# Altium schematic sheet Gateway_Solar_Board.SchDoc
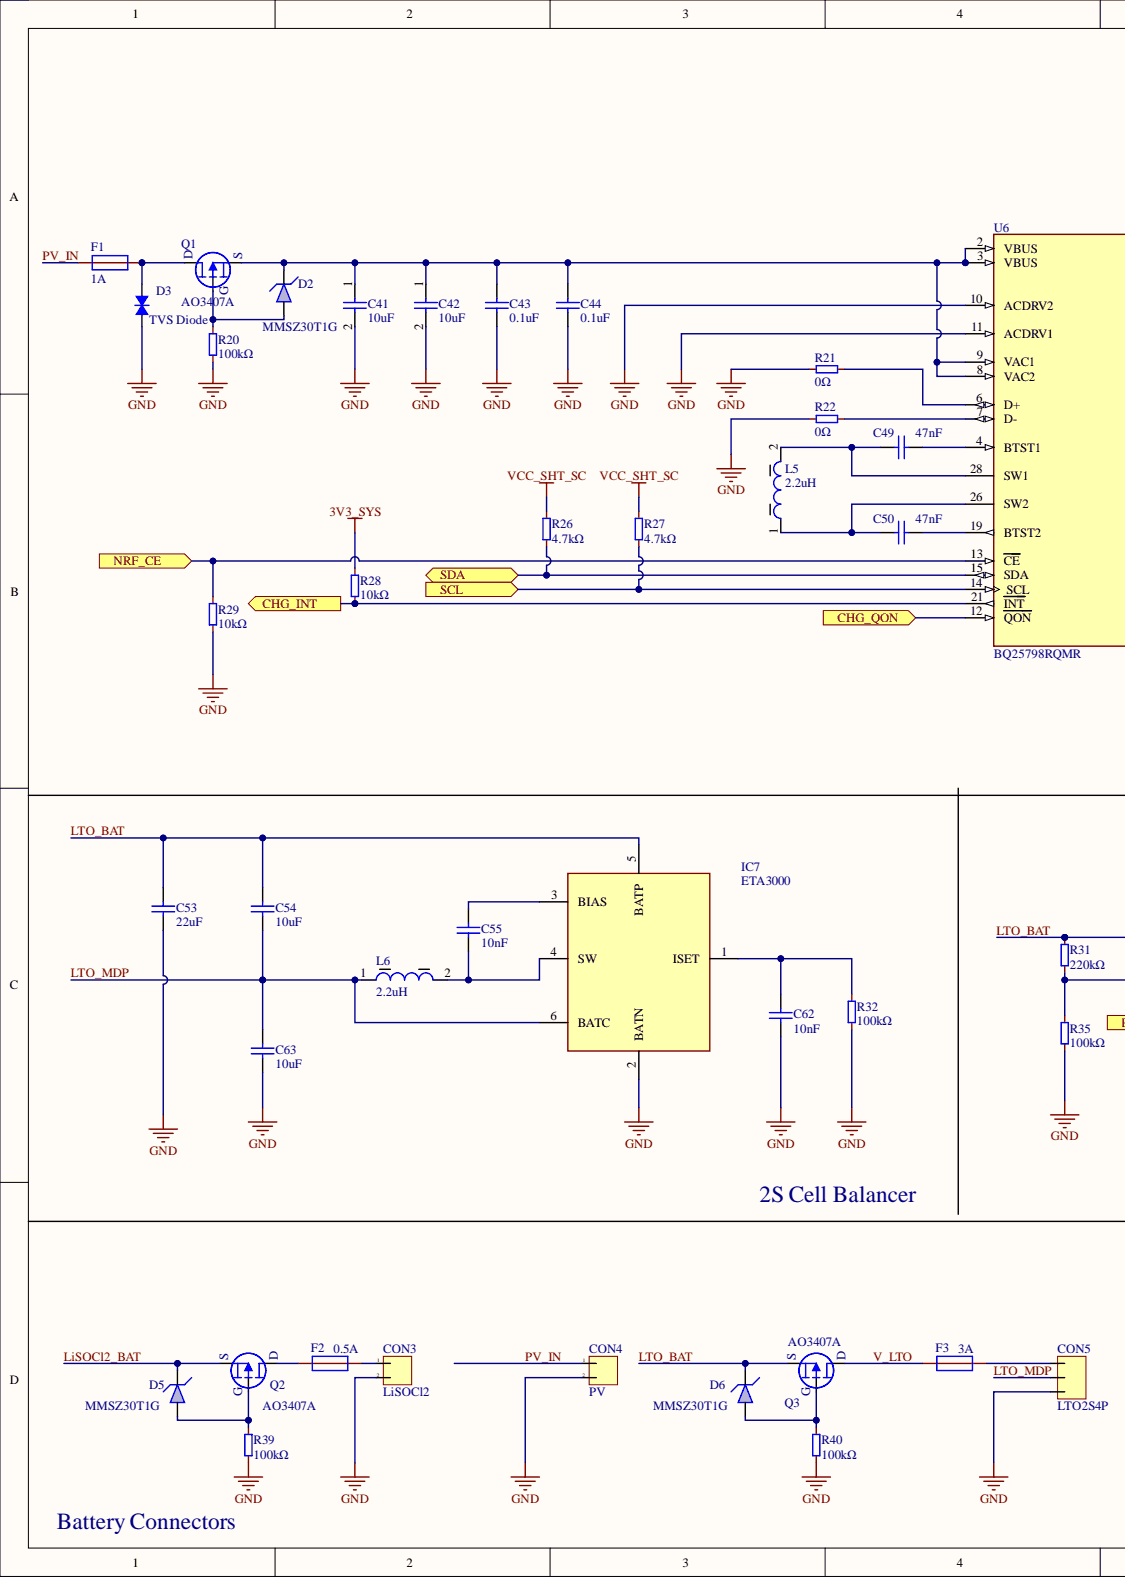
[diagram p0: Gateway_Solar_Board.SchDoc, left]
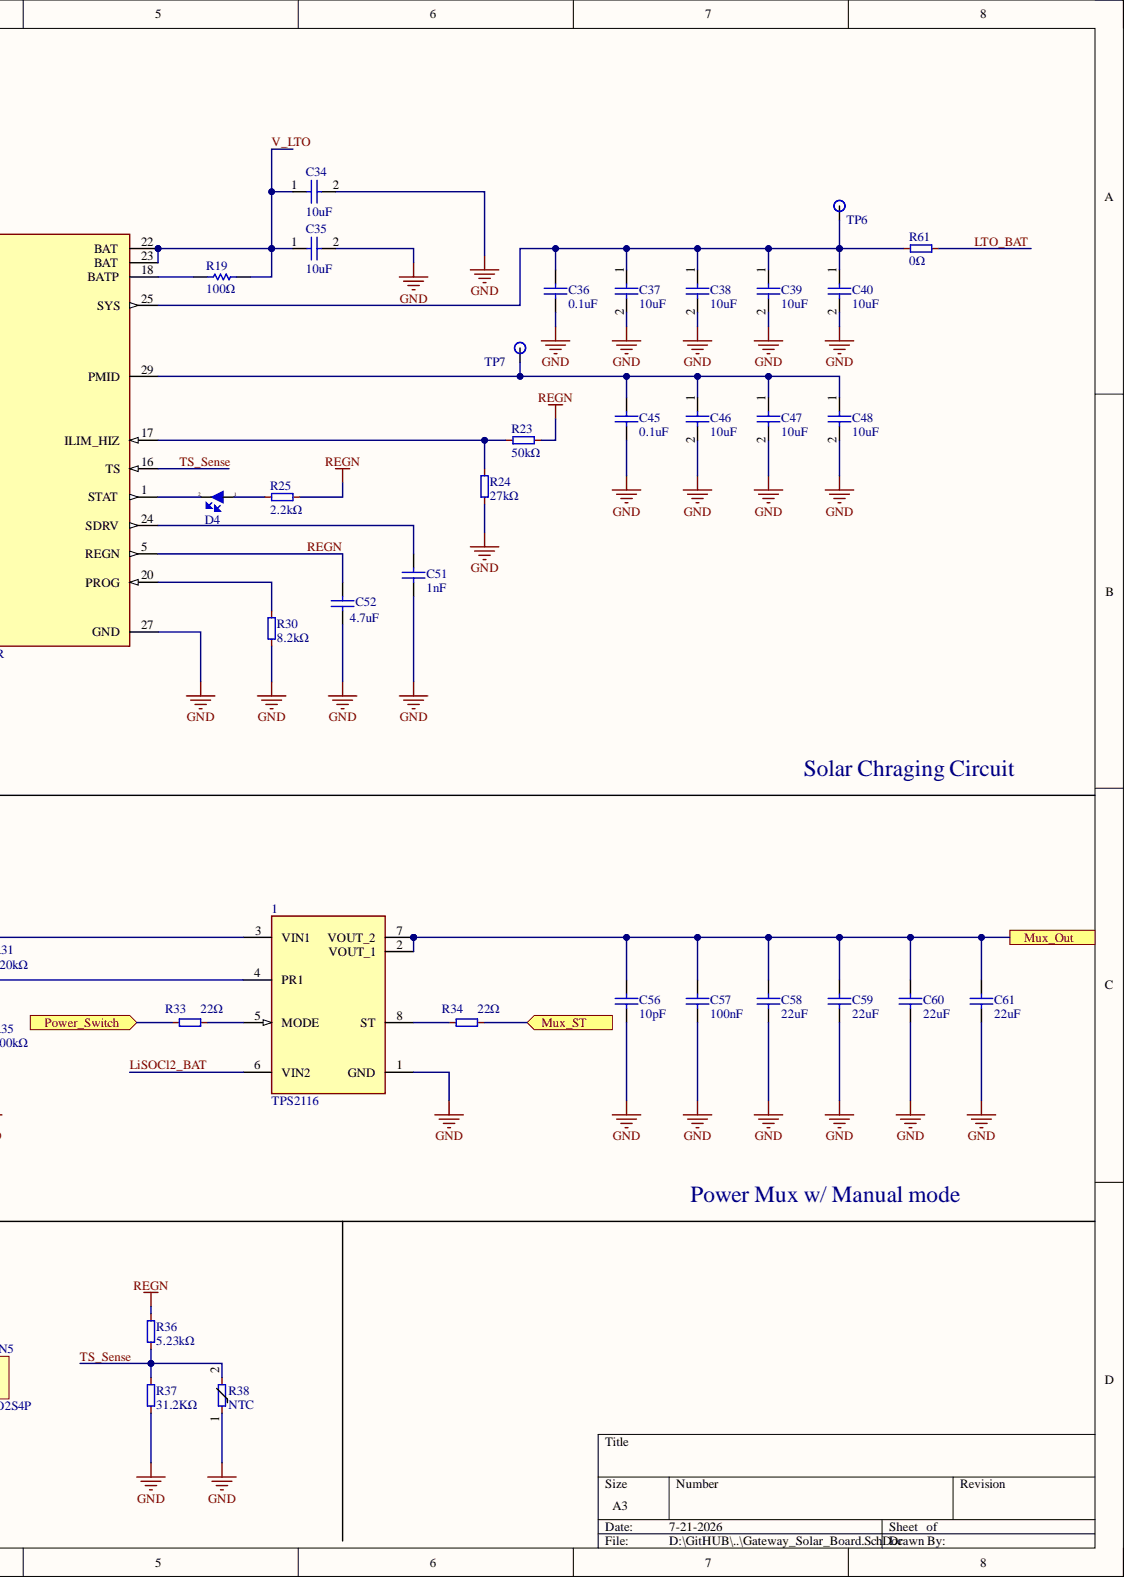
[diagram p1: Gateway_Solar_Board.SchDoc, right]

## Parts
1  TPS2116  [TPS2116]
C34  10uF  [Cap_0805]
C35  10uF  [Cap_0805]
C36  0.1uF  [CAP0402]
C37  10uF  [Cap_0805]
C38  10uF  [Cap_0805]
C39  10uF  [Cap_0805]
C40  10uF  [Cap_0805]
C41  10uF  [Cap_0805]
C42  10uF  [Cap_0805]
C43  0.1uF  [CAP0402]
C44  0.1uF  [CAP0402]
C45  0.1uF  [CAP0402]
C46  10uF  [Cap_0805]
C47  10uF  [Cap_0805]
C48  10uF  [Cap_0805]
C49  47nF  [CAP0402]
C50  47nF  [CAP0402]
C51  value 1nF  [CAPC1005X06N]  (hidden comment: MCD-00198)
C52  4.7uF  [CAP0402]
C53  22uF  [CAP0402]
C54  10uF  [CAP0402]
C55  10nF  [CAP0402]
C56  10pF  [CAP0402]
C57  100nF  [CAP0402]
C58  22uF  [CAP0402]
C59  22uF  [CAP0402]
C60  22uF  [CAP0402]
C61  22uF  [CAP0402]
C62  10nF  [CAP0402]
C63  10uF  [CAP0402]
CON3  LiSOCl2  [2 Pin Relimate 2.54mm Straight]
CON4  PV  [2 Pin Relimate 2.54mm Straight]
CON5  LTO2S4P  [3 Pin Relimate]
D2  MMSZ30T1G  [SOD 80]
D3  TVS Diode  [Diode SMD 214AC]
D4  COLOR  [L_0805]  (value not drawn)
D5  MMSZ30T1G  [SOD 80]
D6  MMSZ30T1G  [SOD 80]
F1  1A  [Fuse - 1206]
F2  0.5A  [Fuse - 1206]
F3  3A  [Fuse - 1206]
IC7  ETA3000  [ETA3000]
L5  2.2uH  [Ind 2.2uH]
L6  2.2uH  [Ind 2.2uH]
Q1  AO3407A  [SOT23]
Q2  AO3407A  [SOT23]
Q3  AO3407A  [SOT23]
R19  100Ω  [RESC0402]
R20  100kΩ  [RESC0402]
R21  0Ω  [RESC0402]
R22  0Ω  [RESC0402]
R23  50kΩ  [RESC0402]
R24  27kΩ  [RESC0402]
R25  2.2kΩ  [RESC0402]
R26  4.7kΩ  [RESC0402]
R27  4.7kΩ  [RESC0402]
R28  10kΩ  [RESC0402]
R29  10kΩ  [RESC0402]
R30  8.2kΩ  [RESC0402]
R31  220kΩ  [RESC0402]
R32  100kΩ  [RESC0402]
R33  22Ω  [RESC0402]
R34  22Ω  [RESC0402]
R35  100kΩ  [RESC0402]
R36  5.23kΩ  [RESC0805]
R37  31.2KΩ  [RESC0805]
R38  NTC  [2 Pin Relimate 2.54mm Straight]
R39  100kΩ  [RESC0402]
R40  100kΩ  [RESC0402]
R61  0Ω  [RESC0402]
TP6  Test Point  [Test Point]  (value not drawn)  (no BOM)
TP7  Test Point  [Test Point]  (value not drawn)  (no BOM)
U6  BQ25798RQMR  [BQ25798RQMR]

## Ports
CHG_INT, CHG_QON, Mux_Out, Mux_ST, NRF_CE, Power_Switch, SCL, SDA

## Nets
3V3_SYS: R28.2
GND: 1.1(GND) C34.2 C35.2 C36.1 C37.2 C38.2 C39.2 C40.2 C41.2 C42.2 C43.1 C44.1 C45.1 C46.2 C47.2 C48.2 C51.1 C52.1 C53.1 C56.2 C57.2 C58.1 C59.1 C60.1 C61.1 C62.1 C63.2 CON3.2 CON4.2 CON5.3 D3.2 IC7.2(BATN) R20.1 R21.1 R22.1 R24.2 R29.1 R30.1 R32.1 R35.1 R37.1 R38.1 R39.1 R40.1 U6.10(ACDRV2) U6.11(ACDRV1) U6.27(GND)
VCC_SHT_SC: R26.2 R27.2
CHG_INT: R28.1 U6.21(INT) ; port CHG_INT
CHG_QON: U6.12(QON) ; port CHG_QON
LiSOCl2_BAT: 1.6(VIN2) D5.1 Q2.2
LTO_BAT: 1.3(VIN1) C53.2 C54.1 D6.1 IC7.5(BATP) Q3.2 R31.2 R61.1
LTO_MDP: C54.2 C63.1 CON5.2 IC7.6(BATC) L6.1
Mux_Out: 1.2(VOUT_1) 1.7(VOUT_2) C56.1 C57.1 C58.2 C59.2 C60.2 C61.2 ; port Mux_Out
Mux_ST: R34.2 ; port Mux_ST
NRF_CE: R29.2 U6.13(CE) ; port NRF_CE
Power_Switch: R33.1 ; port Power_Switch
PV_IN: CON4.1 F1.2
REGN: C52.2 R23.2 R25.1 R36.2 U6.5(REGN)
SCL: R27.1 U6.14(SCL) ; port SCL
SDA: R26.1 U6.15(SDA) ; port SDA
TS_Sense: R36.1 R37.2 R38.2 U6.16(TS)
V_LTO: C34.1 C35.1 F3.1 Q3.3 R19.2 U6.22(BAT) U6.23(BAT)
Net1_4: 1.4(PR1) R31.1 R35.2
Net1_5: 1.5(MODE) R33.2
Net1_8: 1.8(ST) R34.1
NetC36_2: C36.2 C37.1 C38.1 C39.1 C40.1 R61.2 TP6.1 U6.25(SYS)
NetC41_1: C41.1 C42.1 C43.2 C44.2 D2.1 Q1.2 U6.2(VBUS) U6.3(VBUS) U6.8(VAC2) U6.9(VAC1)
NetC45_2: C45.2 C46.1 C47.1 C48.1 TP7.1 U6.29(PMID)
NetC49_1: C49.1 L5.2 U6.28(SW1)
NetC49_2: C49.2 U6.4(BTST1)
NetC50_1: C50.1 L5.1 U6.26(SW2)
NetC50_2: C50.2 U6.19(BTST2)
NetC51_2: C51.2 U6.24(SDRV)
NetC55_1: C55.1 IC7.4(SW) L6.2
NetC55_2: C55.2 IC7.3(BIAS)
NetC62_2: C62.2 IC7.1(ISET) R32.2
NetCON3_1: CON3.1 F2.2
NetCON5_1: CON5.1 F3.2
NetD2_2: D2.2 Q1.1 R20.2
NetD3_1: D3.1 F1.1 Q1.3
NetD4_1: D4.1 R25.2
NetD4_2: D4.2 U6.1(STAT)
NetD5_2: D5.2 Q2.1 R39.2
NetD6_2: D6.2 Q3.1 R40.2
NetF2_1: F2.1 Q2.3
NetR19_1: R19.1 U6.18(BATP)
NetR21_2: R21.2 U6.6(D+)
NetR22_2: R22.2 U6.7(D-)
NetR23_1: R23.1 R24.1 U6.17(ILIM_HIZ)
NetR30_2: R30.2 U6.20(PROG)

## Library data of the parts
1 = TPS2116: 1.6 V to 5.5 V, 2.5-A Low IQ Power Mux; Manufacturer: Texas Instruments; Manufacturer_Part_Number: TPS2116DRLR; Component Kind: Standard; Supplier 1: Digikey; Supplier Part Number 1: TPS2116DRLR; Library Name: macnman_3.0.SchLib
C34 C35 C37-C42 C46-C48 = Cap_0805: Ceramic Capacitor - SMD 0805 10uF 10% 25V X7R; Manufacturer_Part_Number: CL21B106KAYQNNE; Component Kind: Standard; Supplier 1: Evalta; Supplier 2: Robu; Supplier Part Number 1: CL21B106KAYQNNE; Supplier Part Number 2: CL21B106KAYQNNE; Library Name: macnman_3.0.SchLib
C36 C43-C45 = CAP_0402: Ceramic Capacitor - SMD 0.1uF X7R 0402 50V 10%; Manufacturer: Samsung Electro Mechanics; Manufacturer_Part_Number: CL05B104KB54PNC; Component Kind: Standard; Package: 0402; Supplier 1: Evalta; Supplier 2: Robu; Supplier Part Number 1: CL05B104KB54PNC; Supplier Part Number 2: CL05B104KB54PNC; Library Name: macnman_2.0.SchLib
C49 C50 = CAP_0402: Ceramic Capacitor - SMD 7nF X7R 0402 10% 50V; Manufacturer: Samsung Electro Mechanics; Manufacturer_Part_Number: CL05B473KB5VPNC; Component Kind: Standard; Package: 0402; Supplier 1: Robu; Supplier 2: Digikey; Supplier Part Number 1: CL05B473KB5VPNC; Supplier Part Number 2: 1276-CL05B473KB5VPNCCT-ND; Library Name: macnman_2.0.SchLib
C51 = MCD-00198: Ceramic Capacitor - SMD 1nF 25V C0G 5% 0402; Manufacturer: Murata; Manufacturer_Part_Number: GRT1555C1E102JA02J; Component Kind: Standard; Supplier 1: DigiKey; Supplier 2: Mouser; Supplier Part Number 1: 490-GRT1555C1E102JA02JCT-ND; Supplier Part Number 2: 81-GRT1555C1E102JA2J; Library Name: macnman_3.0.SchLib
C52 = CAP_0402: Ceramic Capacitor - SMD X7R 0402 4.7uF 10V 20%; Manufacturer: MURATA; Manufacturer_Part_Number: GRT155R61A475ME13D; Component Kind: Standard; Package: 0402; Supplier 1: Robu; Supplier 2: DigiKey; Supplier Part Number 1: GRT155R61A475ME13D; Supplier Part Number 2: 490-GRT155R61A475ME13DCT-ND; Library Name: macnman_2.0.SchLib
C53 C58-C61 = CAP_0402: Ceramic Capacitor - SMD 22uF X5R 0402 20% 6.3V; Manufacturer: Yageo; Manufacturer_Part_Number: GRM158R61A226ME15D; Component Kind: Standard; Supplier: Robu; Supplier_Part_Number: GRM158R61A226ME15D; Library Name: macnman_2.0.SchLib
C54 C63 = CAP_0402: Ceramic Capacitor - SMD 0402 10uF 20% 6.3V X5R; Manufacturer: MURATA; Manufacturer_Part_Number: GRM155R60J106ME15D; Component Kind: Standard; Supplier: Robu; Supplier_Part_Number: GRM155R60J106ME15D; Library Name: macnman_2.0.SchLib
C55 C62 = CAP_0402: Ceramic Capacitor - SMD C0G 0402 10nF 50V 5%; Manufacturer: MURATA; Manufacturer_Part_Number: GRM1555C1H103JE01D; Component Kind: Standard; Package: 0402; Supplier 1: Robu; Supplier Part Number 1: GRM1555C1H103JE01D; Library Name: macnman_2.0.SchLib
C56 = Capa_0402: Ceramic Capacitor C0G, NP0 0402 10pF 35V; Manufacturer: YAGEO; Manufacturer_Part_Number: CC0402JRNPO9BN100; Component Kind: Standard; Library Name: macnman_2.0.SchLib
C57 = Capa_0402: Ceramic Capacitor C0G, NP0 0402 100nF 35V; Manufacturer: MURATA; Manufacturer_Part_Number: GRM155R61A104KA01J; Component Kind: Standard; Library Name: macnman_2.0.SchLib
CON3 CON4 = 2 Pin Relimate: 2 Pin Connector; Manufacturer: Generic; Component Kind: Net Tie; Manufacturer_Part_Number: Generic; Supplier-1 Part No: 5221; Library Name: macnman_3.0.SchLib
CON5 = 3 Pin Relimate: 3 Pin Connector; Manufacturer: Generic; Component Kind: Net Tie; Manufacturer_Part_Number: Generic; Package: 2.54 3POS; Library Name: macnman_3.0.SchLib
D2 D5 = ZENER_DIODE: Zener Diode  3V3 SOD-80 1/5WATT; Manufacturer: Onsemi; Parameter 1: MMSZ30T1Gv; Suplier: Robu; Component Kind: Standard; Manufacturer_Part_Number: MMSZ30T1G; Package: SOD-80; Library Name: macnman_2.0.SchLib
D3 = TVS Diode: 0.35pF 20kV Bidirectional Discrete TVS; Manufacturer: Littelfuse; Manufacturer_Part_Number: SMBJ16A; Component Kind: Standard; Library Name: macnman_3.0.SchLib
D4 = L0805: LED 0805 SMD; Manufacturer: Everlight; Manufacturer_Part_Number: 17-21SURC/S530-A3/4T; Component Kind: Standard; Supplier 1: Evalta; Supplier 2: Sharvi; Supplier Part Number 1: 17-21SURC/S530-A3/4T; Supplier Part Number 2: ST2103SD2639; Library Name: macnman_3.0.SchLib
D6 = ZENER_DIODE: Zener Diode  3V3 SOD-80 1/5WATT; Manufacturer: Onsemi; Suplier: Robu; Component Kind: Standard; Manufacturer_Part_Number: MMSZ30T1G; Package: SOD-80; Parameter 1: MMSZ30T1G; Library Name: macnman_2.0.SchLib
F1 = Fuse: 1 A 63 V 63 V Fuse Board Mount (Cartridge Style Excluded) Surface Mount 1206 (3216 Metric); Manufacture: Bel Fuse Inc; Manufacturer_Part_Number: 0685T1000-01; Component Kind: Standard; Supplier 1: Digikey; Supplier Part Number 1: 0685T1000-01; Library Name: macnman_2.0.SchLib
F2 = Fuse: 500 mA 63 V Fuse Board Mount (Cartridge Style Excluded) Surface Mount 1206 (3216 Metric); Manufacture: Yageo; Manufacturer_Part_Number: JB12S5000R; Component Kind: Standard; Supplier 1: Digikey; Supplier Part Number 1: JB12S5000R; Library Name: macnman_2.0.SchLib
F3 = Fuse: 3 A 63 V 50A Fuse Board Mount Surface Mount 1206 (3216 Metric); Manufacture: Bel Fuse Inc; Manufacturer_Part_Number: 0685T3000-01; Component Kind: Standard; Supplier 1: Digikey; Supplier Part Number 1: 0685T3000-01; Library Name: macnman_2.0.SchLib
IC7 = ETA3000: Inductive 2S Cell Balancer IC, 2uA Iq, 2A Imax; Manfacturer: ETA Solution; Component Kind: Standard; Manufacturer_Part_Number: ETA3000; Supplier: Alibaba; Supplier_Part_Number: ETA3000; Library Name: macnman_3.0.SchLib
L5 L6 = Inductor: FIXED Imductor - SMD 2.2UH 6.5A 20 MOHM 20%; Manufacturer: Wurth; Manufacturer_Part_Number: 74437346022; Component Kind: Standard; Library Name: Inductor_2.2uH.SchLib; Supplier 1: DigiKey; Supplier Part Number 1: 732-3372-1-ND
Q1 Q2 = MOS_P_Chann: MOSDET P-CHANNEL SOT-23 4A 30V; Manufacturer: Alpha and Omega Semiconductor; Component Kind: Standard; Manufacturer_Part_Number: AO3407A; Package: SOT-23; Parameter 1: AO3407A; Supplier: Robu; Library Name: macnman_2.0.SchLib
Q3 = MOS_P_Chann: MOSDET P-CHANNEL SOT-23 4A 30V; Manufacturer: Alpha and Omega Semiconductors; Component Kind: Standard; Manufacturer_Part_Number: AO3407A; Package: SOT-23; Supplier: Robu; Supplier_Part_Number: AO3407A; Library Name: macnman_2.0.SchLib
R19 = MCD-00119: Resistor 100Ω 0402 1% 1/16W; Manufacturer: YAGEO; Manufacturer_Part_Number: RC0402FR-07100RL; Component Kind: Standard; Value: 100Ω; Library Name: macnman_3.0.SchLib
R20 R32 R35 R39 R40 = Resister: Thick Flim Resistor - SMD 100k 0805 0.5% 100ppm 1/8W; Manufacturer: Yageo; Manufacturer_Part_Number: RC0402DR-07100KL; Component Kind: Standard; Package: 0805; Supplier: Robu; Supplier_Part_Number: RC0402DR-07100KL; Library Name: macnman_3.0.SchLib
R21 R22 R61 = RES_0402: Thick Film Resistors - SMD 0Ohm 0402 1/16W; Manufacturer: YAGEO; Manufacturer_Part_Number: RC0402FR-070RL; Component Kind: Standard; ComponentLink1Description: New link; Supplier: Robu; Supplier_Part_Number: RC0402FR-070RL; Library Name: macnman_2.0.SchLib
R23 = RES_0603: Thin Film Resistors 50K 1% 50PPM 1/1 6W 0402; Manufacturer: YAGEO; Manufacturer_Part_Number: RT0402BRD0750KL; Component Kind: Standard; ComponentLink1Description: New link; Package: RT0603BRD0750KL; Supplier: Robu; Library Name: macnman_2.0.SchLib
R24 = RES_0603: Thick Flim Resistor - SMD 27k Ohms 1/10W 0402 1% 100ppm; Manufacturer: YAGEO; Manufacturer_Part_Number: AC0402FR-0727KL; Component Kind: Standard; ComponentLink1Description: New link; Package: AC0603FR-0727KL; Supplier: Robu; Library Name: macnman_2.0.SchLib
R25 = RES_0402: Thin Film Resistors - SMD 2.2K 1% 100PPM 1/16W 0402; Manufacturer: YAGEO; Manufacturer_Part_Number: RC0402FR-072K2L; Component Kind: Standard; ComponentLink1Description: New link; Supplier: Robu; Supplier_Part_Number: RC0402FR-072K2L; Library Name: macnman_2.0.SchLib
R26 R27 = RES_0402: Thin Film Resistors - SMD 4.7K 1% 100PPM 1/10W 0402 100mW; Manufacturer: Panasonic; Manufacturer_Part_Number: ERJ2RKF4701X; Component Kind: Standard; ComponentLink1Description: New link; Supplier: Robu; Supplier_Part_Number: ERJ2RKF4701X; Library Name: macnman_2.0.SchLib
R28 R29 = Resister: Thick Flim Resistor - 10k 0402 100ppm 1% 1/10W; Manufacturer: Pansonic; Manufacturer_Part_Number: ERJ2RKF1002X; Component Kind: Standard; Package: 0402; Supplier 1: Robu; Supplier Part Number 1: P10.0KLCT-ND; Library Name: macnman_3.0.SchLib
R30 = RES_0402: Thin Film Resistors - SMD 8.2K 1% 100PPM 1/16W 0402; Manufacturer: Panasonic; Manufacturer_Part_Number: RC0402FR-078K2L; Component Kind: Standard; ComponentLink1Description: New link; Supplier: Robu; Supplier_Part_Number: RC0402FR-078K2L; Library Name: macnman_2.0.SchLib
R31 = Resister: Thick File Resistor - SMD 0402 220k ohm 100ppm 1% 1/8W; Manufacturer: Yageo; Manufacturer_Part_Number: RC0402FR-07220KL; Component Kind: Standard; Package: 0805; Supplier: Robu; Supplier_Part_Number: RC0402FR-07220KL; Library Name: macnman_3.0.SchLib
R33 R34 = RES_0402: Thin Film Resistors - SMD 22ohm 1% 100PPM 1/16W 0402; Manufacturer: YAGEO; Manufacturer_Part_Number: RC0402FR-0722RL; Component Kind: Standard; ComponentLink1Description: New link; Supplier: Robu; Supplier_Psrt_Number: RC0402FR-0722RL; Library Name: macnman_2.0.SchLib
R36 = RES_0402: Thick Film Resistors - SMD 5.23K 1%  1/8W 0805; Manufacturer: YAGEO; Manufacturer_Part_Number: RC0805FR-075K23L; Component Kind: Standard; ComponentLink1Description: New link; Supplier: Robu; Supplier 1: DigiKey; Supplier Part Number 1: 541-RCA0402100KFKEDSRDKR-ND; Supplier_Part_Number: RC0805FR-075K23L; Library Name: macnman_2.0.SchLib
R37 = RES_0805: Thin Film Resistors 100K 0.1% 50PPM 1/1 6W 0805; Manufacturer: YAGEO; Manufacturer_Part_Number: RT0805BRD0731K2L; Component Kind: Standard; ComponentLink1Description: New link; Supplier: Robu; Supplier_Part_Number: RT0805BRD0731K2L; Library Name: macnman_2.0.SchLib
R38 = NTC_Thermistor: NTC THERMISTOR 10KOHM 1%; Manufacturer_Part_Number: 103AT-2; Manfacturer: Semitec USA; Component Kind: Standard; Library Name: NTC_Thermistor.SchLib; Supplier 1: DigiKey; Supplier Part Number 1: 103AT-2
TP6 TP7 = Test Point: Test Point 1mm; Library Name: macnman_2.0.SchLib
U6 = BQ25798RQMR: IÂ²C controlled, 1-4-cell, 5-A buck-boost solar battery charger with dual-input selector and MPPT; Manufacturer: Texas Instruments; Component Kind: Standard; Library Name: BQ25798RQMR.SchLib; Manufacturer_Part_Number: BQ25798RQMR; Supplier 1: DigiKey; Supplier Part Number 1: 296-BQ25798RQMRDKR-ND
